# Altium schematic sheet Mako DSP Power R2.0.SchDoc("Mako DSP Power R2.0")
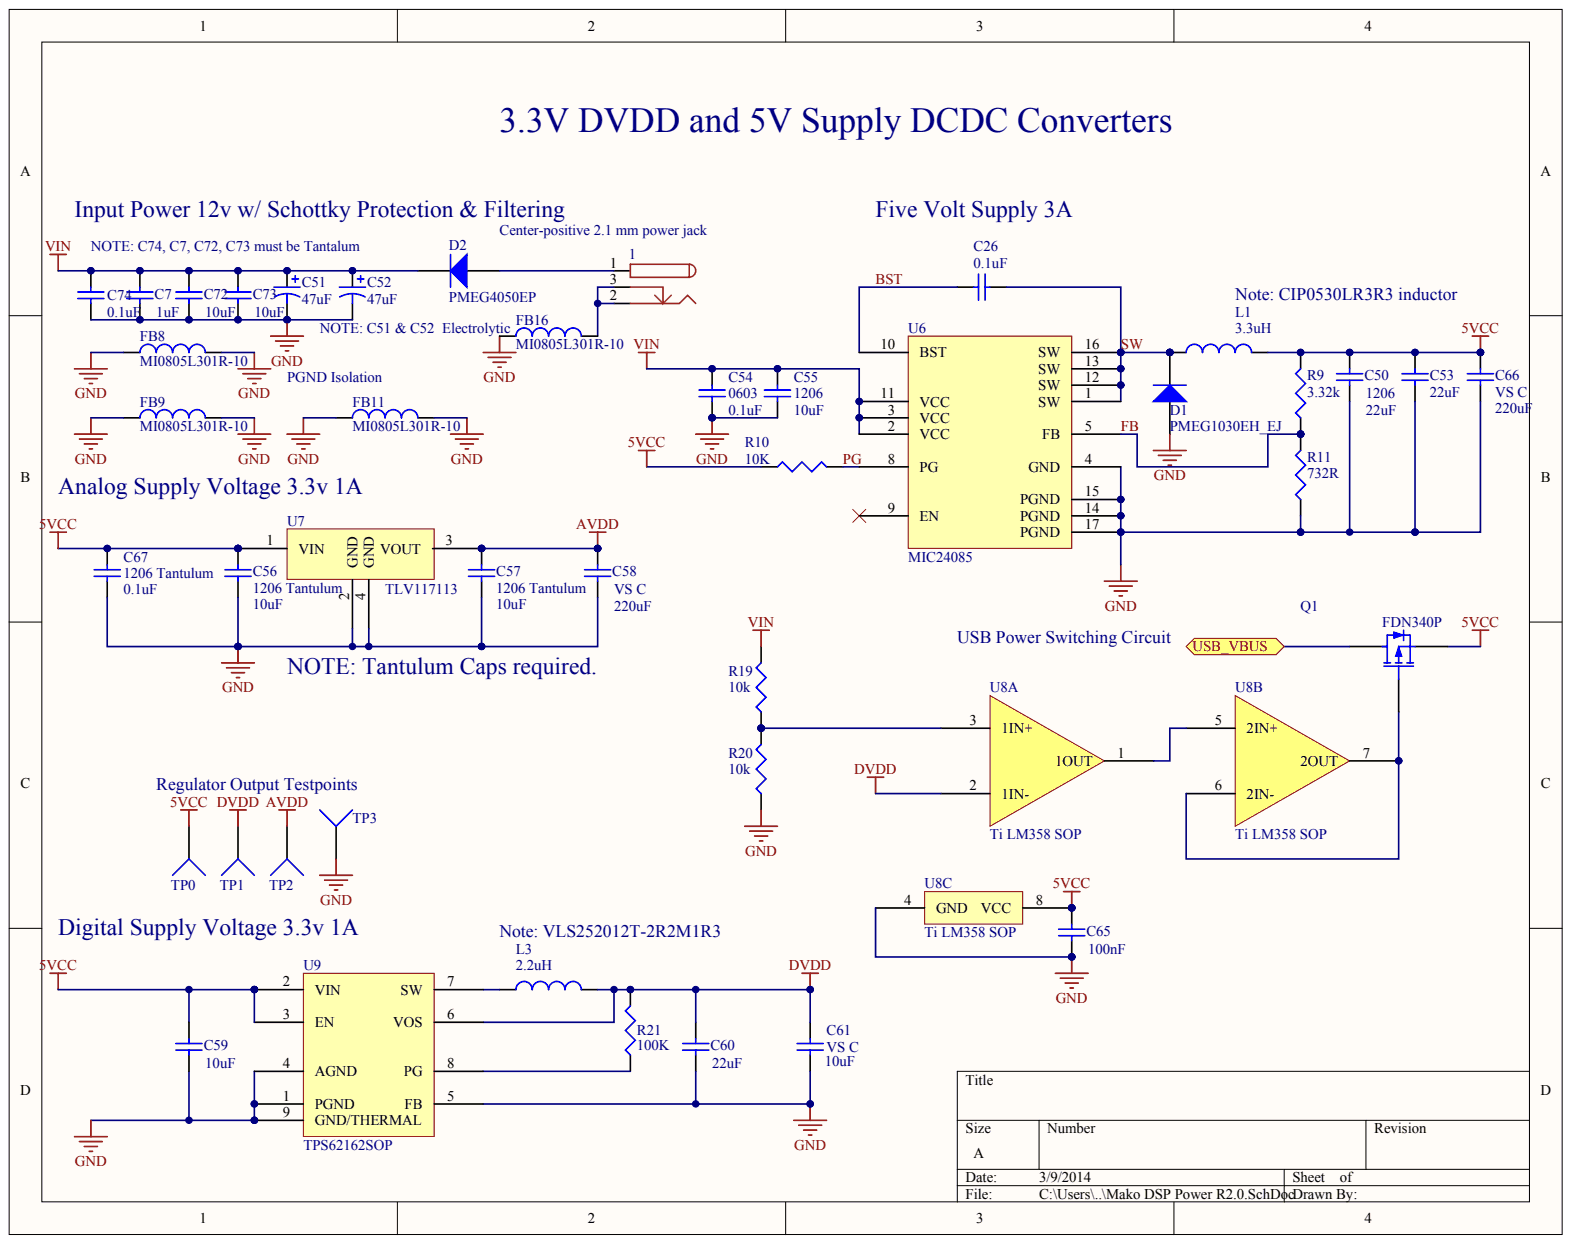
[diagram p0: Mako DSP Power R2.0.SchDoc]

## Parts
1  Center-positive 2.1 mm power jack
C7  value 1uF  (hidden comment: Cap Semi)
C26  value 0.1uF  (hidden comment: Cap Semi)
C50  1206  value 22uF
C51  value 47uF  (hidden comment: Cap Pol1)
C52  value 47uF  (hidden comment: Cap Pol1)
C53  value 22uF  (hidden comment: Cap Semi)
C54  0603  value 0.1uF
C55  1206  value 10uF
C56  1206 Tantulum  value 10uF
C57  1206 Tantulum  value 10uF
C58  VS C  value 220uF
C59  value 10uF  (hidden comment: Cap Semi)
C60  value 22uF  (hidden comment: Cap Semi)
C61  VS C  value 10uF
C65  value 100nF  (hidden comment: Cap Semi)
C66  VS C  value 220uF
C67  1206 Tantulum  value 0.1uF
C72  value 10uF  (hidden comment: Cap Semi)
C73  value 10uF  (hidden comment: Cap Semi)
C74  value 0.1uF  (hidden comment: Cap Semi)
D1  PMEG1030EH_EJ
D2  PMEG4050EP
FB8  MI0805L301R-10
FB9  MI0805L301R-10
FB11  MI0805L301R-10
FB16  MI0805L301R-10
L1  value 3.3uH  (hidden comment: Inductor)
L3  value 2.2uH  (hidden comment: Inductor)
Q1  FDN340P
R9  value 3.32k  (hidden comment: Res3)
R10  value 10K  (hidden comment: Res3)
R11  value 732R  (hidden comment: Res3)
R19  value 10k  (hidden comment: Res3)
R20  value 10k  (hidden comment: Res3)
R21  value 100K  (hidden comment: Res3)
TP0  Socket  (value not drawn)
TP1  Socket  (value not drawn)
TP2  Socket  (value not drawn)
TP3  Socket  (value not drawn)
U6  MIC24085
U7  TLV117113
U8  Ti LM358 SOP  (parts A,B,C)
U9  TPS62162SOP

## Ports
USB_VBUS

## Nets
5VCC: C50.2 C53.1 C56.1 C59.2 C65.1 C66.2 C67.2 L1.2 Q1.2 R9.2 R10.1 TP0.1 U7.1(VIN) U8.8(VCC) U9.2(VIN) U9.3(EN)
AVDD: C57.1 C58.1 TP2.1 U7.3(VOUT)
DVDD: C60.2 C61.1 L3.2 R21.2 TP1.1 U8.2(1IN-) U9.6(VOS)
GND: C7.1 C50.1 C51.2 C52.2 C53.2 C54.1 C55.1 C56.2 C57.2 C58.2 C59.1 C60.1 C61.2 C65.2 C66.1 C67.1 C72.1 C73.1 C74.1 D1.1 FB8.1 FB8.2 FB9.1 FB9.2 FB11.1 FB11.2 FB16.1 R11.1 R20.1 TP3.1 U6.4(GND) U6.14(PGND) U6.15(PGND) U6.17(PGND) U7.2(GND) U7.4(GND) U8.4(GND) U9.1(PGND) U9.4(AGND) U9.5(FB) U9.9(GND/THERMAL)
VIN: C7.2 C51.1 C52.1 C54.2 C55.2 C72.2 C73.2 C74.2 D2.1 R19.2 U6.2(VCC) U6.3(VCC) U6.11(VCC)
BST: C26.2 U6.10(BST)
FB: R9.1 R11.2 U6.5(FB)
PG: R10.2 U6.8(PG)
SW: C26.1 D1.2 L1.1 U6.1(SW) U6.12(SW) U6.13(SW) U6.16(SW)
USB_VBUS: Q1.3 ; port USB_VBUS
Net1_1: 1.1 D2.2
Net1_2: 1.2 1.3 FB16.2
NetL3_1: L3.1 U9.7(SW)
NetQ1_1: Q1.1 U8.6(2IN-) U8.7(2OUT)
NetR19_1: R19.1 R20.2 U8.3(1IN+)
NetR21_1: R21.1 U9.8(PG)
NetU8_1: U8.1(1OUT) U8.5(2IN+)

Not connected: U6 9(EN)

## Library data of the parts
FB8 FB9 FB11 FB16 L1 L3 = (no symbol name): Code_IEC: 1005; Published: 8-Jun-2000; PackageReference: 0402-A; Code_JEITA: 0402; Publisher: Altium Limited
  FB8 FB9 FB11 FB16: LatestRevisionDate: 17-Jul-2002; LatestRevisionNote: Re-released for DXP Platform.
  L1 L3: LatestRevisionDate: 29-May-2009; LatestRevisionNote: IPC-7351 Footprint Added.; PackageDescription: Chip Inductor
1 C7 C26 C50-C61 C65-C67 C72-C74 D1 D2 Q1 R9-R11 R19-R21 TP0-TP3 U6-U9 = (no symbol name)
  1: Published: 17-Dec-2003; DatasheetDocument: 09-May-2002; ComponentLink1Description: Manufacturer Link; ComponentLink1URL: http://domino.kycon.com/internet/Products.html
  C7 C26 C50 C53-C61 C65-C67 C72-C74: Published: 8-Jun-2000; LatestRevisionDate: 29-May-2009; LatestRevisionNote: IPC-7351 Footprint Added.; PackageReference: C1206; PackageDescription: Chip Capacitor
  C51 C52: Published: 8-Jun-2000; LatestRevisionDate: 17-Jul-2002; LatestRevisionNote: Re-released for DXP Platform.; PackageReference: RB7.6-15; PackageDescription: Polarized Capacitor (Radial); 2 Leads
  D1 D2: Published: 8-Jun-2000; LatestRevisionDate: 17-Jul-2002; LatestRevisionNote: Re-released for DXP Platform.; PackageVersion: Sep-1996; PackageReference: SMC; PackageDescription: DO-214-AB/SMC; 2 C-Bend Leads; Body 7.9 x 5.9 mm, inc. leads (LxW); Code_JEDEC: DO-214-AB
  Q1: Published: 8-Jun-2000; LatestRevisionDate: 17-Jul-2002; LatestRevisionNote: Re-released for DXP Platform.; PackageVersion: 1995/1996; PackageReference: E3; PackageDescription: TO, Flat Index; 3 In-Line, Axial Leads; Body Dia. 4.6mm; Lead Dia. 0.5 mm (max)
  R9-R11 R19-R21: Published: 8-Jun-2000; LatestRevisionDate: 29-May-2009; LatestRevisionNote: IPC-7351 Footprint Added.; PackageReference: J1-0603; PackageDescription: Chip Resistor
  TP0-TP3: LatestRevisionDate: 17-Jul-2002; LatestRevisionNote: Re-released for DXP Platform.
  1 C7 C26 C50-C61 C65-C67 C72-C74 D1 D2 Q1 R9-R11 R19-R21 TP0-TP3: Publisher: Altium Limited
